# Altium schematic sheet Gateway.SchDoc
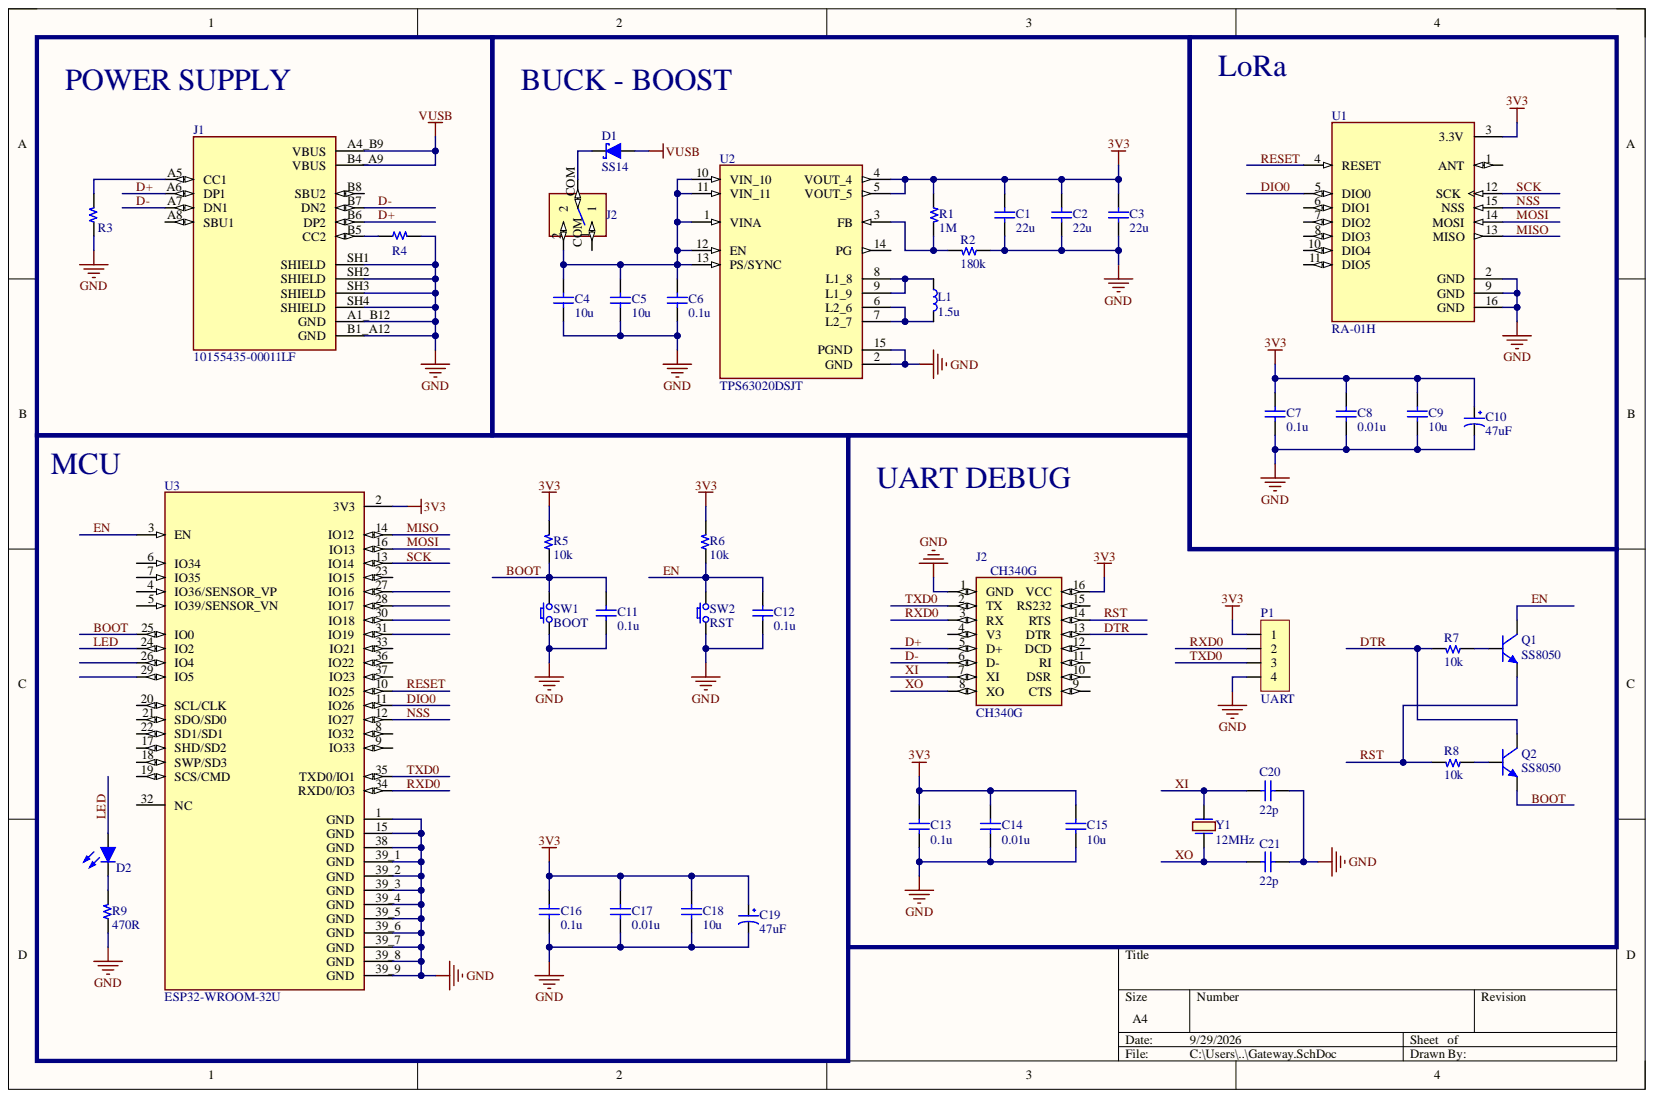
[diagram p0: Gateway.SchDoc]

## Parts
C1  22u  [C 0603]
C2  22u  [C 0603]
C3  22u  [C 0603]
C4  10u  [C 0603]
C5  10u  [C 0603]
C6  0.1u  [C 0603]
C7  0.1u  [C 0603]
C8  0.01u  [C 0603]
C9  10u  [C 0603]
C10  47uF  [tu tantalum smd3216]
C11  0.1u  [C 0603]
C12  0.1u  [C 0603]
C13  0.1u  [C 0603]
C14  0.01u  [C 0603]
C15  10u  [C 0603]
C16  0.1u  [C 0603]
C17  0.01u  [C 0603]
C18  10u  [C 0603]
C19  47uF  [tu tantalum smd3216]
C20  22p  [C 0603]
C21  22p  [C 0603]
D1  SS14  [DO-214AC (SMA)]
D2  Led  [Led 0603]  (value not drawn)
J1  10155435-00011LF  [AMPHENOL_10155435-00011LF]
J2  SK12D07VG4  [SK12D07VG4]  (value not drawn)
J2  CH340G  [SOIC-16]
L1  1.5u  [Inductor 0420]
P1  UART  [HDR/Header/4/2mm]
Q1  SS8050  [SOT-23]
Q2  SS8050  [SOT-23]
R1  1M  [R 0603]
R2  180k  [R 0603]
R3  5.1k  [R 0603]  (value not drawn)
R4  5.1k  [R 0603]  (value not drawn)
R5  10k  [R 0603]
R6  10k  [R 0603]
R7  10k  [R 0603]
R8  10k  [R 0603]
R9  470R  [R 0603]
SW1  BOOT  [SW/2/SMD]
SW2  RST  [SW/2/SMD]
U1  RA-01H  [XCVR_RA-01H]
U2  TPS63020DSJT  [IC_TPS63020DSJT]
U3  ESP32-WROOM-32U  [XCVR_ESP32-WROOM-32U]
Y1  12MHz  [Crystal HC49S DIP]

## Nets
3V3: C1.1 C2.1 C3.1 C7.1 C8.1 C9.1 C10.1 C13.2 C14.1 C15.2 C16.1 C17.1 C18.1 C19.1 J2.16(VCC) P1.1 R1.1 R5.2 R6.2 U1.3(3.3V) U2.4(VOUT_4) U2.5(VOUT_5) U3.2(3V3)
GND: C1.2 C2.2 C3.2 C4.2 C5.2 C6.2 C7.2 C8.2 C9.2 C10.2 C11.2 C12.2 C13.1 C14.2 C15.1 C16.2 C17.2 C18.2 C19.2 C20.1 C21.1 J1.A1_B12(GND) J1.B1_A12(GND) J1.SH1(SHIELD) J1.SH2(SHIELD) J1.SH3(SHIELD) J1.SH4(SHIELD) J2.1 P1.4 R2.1 R3.1 R4.2 R9.2 SW1.1 SW2.1 U1.2(GND) U1.9(GND) U1.16(GND) U2.2(GND) U2.15(PGND) U3.1(GND) U3.15(GND) U3.38(GND) U3.39_1(GND) U3.39_2(GND) U3.39_3(GND) U3.39_4(GND) U3.39_5(GND) U3.39_6(GND) U3.39_7(GND) U3.39_8(GND) U3.39_9(GND)
VUSB: D1.1 J1.A4_B9(VBUS) J1.B4_A9(VBUS)
BOOT: C11.1 Q2.2 R5.1 SW1.2 U3.25(IO0)
D+: J1.A6(DP1) J1.B6(DP2) J2.5(D+)
D-: J1.A7(DN1) J1.B7(DN2) J2.6(D-)
DIO0: U1.5(DIO0) U3.11(IO26)
DTR: J2.13(DTR) Q2.3 R7.2
EN: C12.1 Q1.3 R6.1 SW2.2 U3.3(EN)
LED: D2.1 U3.24(IO2)
MISO: U1.13(MISO) U3.14(IO12)
MOSI: U1.14(MOSI) U3.16(IO13)
NSS: U1.15(NSS) U3.12(IO27)
RESET: U1.4(RESET) U3.10(IO25)
RST: J2.14(RTS) Q1.2 R8.2
RXD0: J2.3(RX) P1.2 U3.34(RXD0/IO3)
SCK: U1.12(SCK) U3.13(IO14)
TXD0: J2.2 P1.3 U3.35(TXD0/IO1)
XI: C20.2 J2.7(XI) Y1.1
XO: C21.2 J2.8(XO) Y1.2
NetC4_1: C4.1 C5.1 C6.1 J2.2 U2.1(VINA) U2.10(VIN_10) U2.11(VIN_11) U2.12(EN) U2.13(PS/SYNC)
NetD1_2: D1.2 J2.COM
NetD2_2: D2.2 R9.1
NetJ1_A5: J1.A5(CC1) R3.2
NetJ1_B5: J1.B5(CC2) R4.1
NetL1_1: L1.1 U2.8(L1_8) U2.9(L1_9)
NetL1_2: L1.2 U2.6(L2_6) U2.7(L2_7)
NetQ1_1: Q1.1 R7.1
NetQ2_1: Q2.1 R8.1
NetR1_2: R1.2 R2.2 U2.3(FB)

Not connected: J1 A8(SBU1) B8(SBU2); J2 4(V3) 9(CTS) 10(DSR) 11(RI) 12(DCD) 15(RS232); U1 1(ANT) 6(DIO1) 7(DIO2) 8(DIO3) 10(DIO4) 11(DIO5); U2 14(PG); U3 4(IO36/SENSOR_VP) 5(IO39/SENSOR_VN) 6(IO34) 7(IO35) 8(IO32) 9(IO33) 17(SHD/SD2) 18(SWP/SD3) 19(SCS/CMD) 20(SCL/CLK) 21(SDO/SD0) 22(SD1/SD1) 23(IO15) 26(IO4) 27(IO16) 28(IO17) 29(IO5) 30(IO18) 31(IO19) 32(NC) 33(IO21) 36(IO22) 37(IO23)

## Library data of the parts
C1-C9 C11-C18 = Capacitor NP: Capacitor non-pol; Component Kind: Standard; Library Name: chipfc_altium_libs.IntLib
C10 C19 = Tu tantalum 47uF 10V SMD3216: Dán; Don_Vi: Con; Ma_Hang: MH007497; So_Chan: 2; Ten_Linh_Kien: Tụ tantalum 476A-47uF 10V SMD3216; Chung_Loai: Dán; Component Kind: Standard; Library Name: Altium_Lib_by_KhueNguyenCreator.IntLib
C20 C21 = Capacitor NP: Ceramic capacitor; Component Kind: Standard; Library Name: chipfc_altium_libs.IntLib
D1 = Diode Schottky: Schottky Diode; Component Kind: Standard; Library Name: chipfc_altium_libs.IntLib
D2 = Led: Typical LED; Component Kind: Standard; Library Name: chipfc_altium_libs.IntLib
J2 = SK12D07VG4: Switch Slide ON ON SPDT Side Slide 0.3A 30VDC PC Pins Bracket Mount/Through Hole Bulk; MF: C&K; Check_prices: https://www.snapeda.com/parts/SK12D07VG4/C%2526K/view-part/?ref=eda; Component Kind: Standard; Library Name: SK12D07VG4.IntLib; MP: SK12D07VG4; Package: None; SnapEDA_Link: https://www.snapeda.com/parts/SK12D07VG4/C%2526K/view-part/?ref=snap
J2 = CH340G: USB Interface Integrated Circuit; MF: WCH; Availability: No availability data; Check_prices: https://www.snapeda.com/parts/Ch340G/WCH/view-part/6287223/?ref=eda; Component Kind: Standard; Library Name: chipfc_altium_libs.IntLib; MP: Ch340G; Package: Package; Price: None; SnapEDA_Link: https://www.snapeda.com/parts/Ch340G/WCH/view-part/6287223/?ref=snap
L1 = L1: Inductor; Value: 150 mH; Component Kind: Standard; Library Name: syhaunguyen.SchLib
P1 = Header 4: Header, 4-Pin; Component Kind: Standard; Library Name: chipfc_altium_libs.IntLib
Q1 Q2 = Transistor NPN: NPN Bipolar Transistor; Component Kind: Standard; Library Name: chipfc_altium_libs.IntLib
R1-R9 = Resistor: Component Kind: Standard; Description: Resistor; Library Name: chipfc_altium_libs.IntLib
SW1 SW2 = SW SPST: Tact switch 2 pin; Component Kind: Standard; Library Name: chipfc_altium_libs.IntLib
U1 = RA-01H: MÃ´-Ä‘un thu phÃ¡t LoRaâ„¢ ISM < 1GHz chung KhÃ´ng bao gá»“m Äƒng-ten 803 MHz ~ 930 MHz GiÃ¡ treo bá» máº·t; MF: AI-Thinker; Check_prices: https://www.snapeda.com/parts/RA-01H/AI-Thinker/view-part/?ref=eda; Component Kind: Standard; Library Name: RA-01H.IntLib; MP: RA-01H; Package: Package; SnapEDA_Link: https://www.snapeda.com/parts/RA-01H/AI-Thinker/view-part/?ref=snap
U2 = TPS63020DSJT: High Efficiency Single Inductor Buck-Boost Converter with 4A Switch; MF: Texas Instruments; Check_prices: https://www.snapeda.com/parts/TPS63020DSJT/Texas+Instruments/view-part/?ref=eda; Component Kind: Standard; Library Name: TPS63020DSJT.IntLib; MP: TPS63020DSJT; Package: VSON-14 Texas Instruments; SnapEDA_Link: https://www.snapeda.com/parts/TPS63020DSJT/Texas+Instruments/view-part/?ref=snap
U3 = ESP32-WROOM-32U: Bluetooth, WiFi 802.11b/g/n, Bluetooth v4.2 +EDR, Class 1, 2 and 3 Transceiver Module 2.4GHz ~ 2.5GHz Antenna Not Included, U.FL Surface Mount; MF: Espressif Systems; Check_prices: https://www.snapeda.com/parts/ESP32-WROOM-32U/Espressif+Systems/view-part/?ref=eda; Component Kind: Standard; Library Name: ESP32-WROOM-32U.IntLib; MP: ESP32-WROOM-32U; Package: NON STANDARD-39 Espressif; SnapEDA_Link: https://www.snapeda.com/parts/ESP32-WROOM-32U/Espressif+Systems/view-part/?ref=snap
Y1 = Crystal: Crystal 2p 3215; Component Kind: Standard; Library Name: chipfc_altium_libs.IntLib
J1 = 10155435-00011LF: Availability: Unavailable; Component Kind: Standard; Library Name: 10155435-00011LF.IntLib; MP: 10155435-00011LF; Package: None; Price: None
